# Altium schematic sheet Circuit_SCH.SchDoc
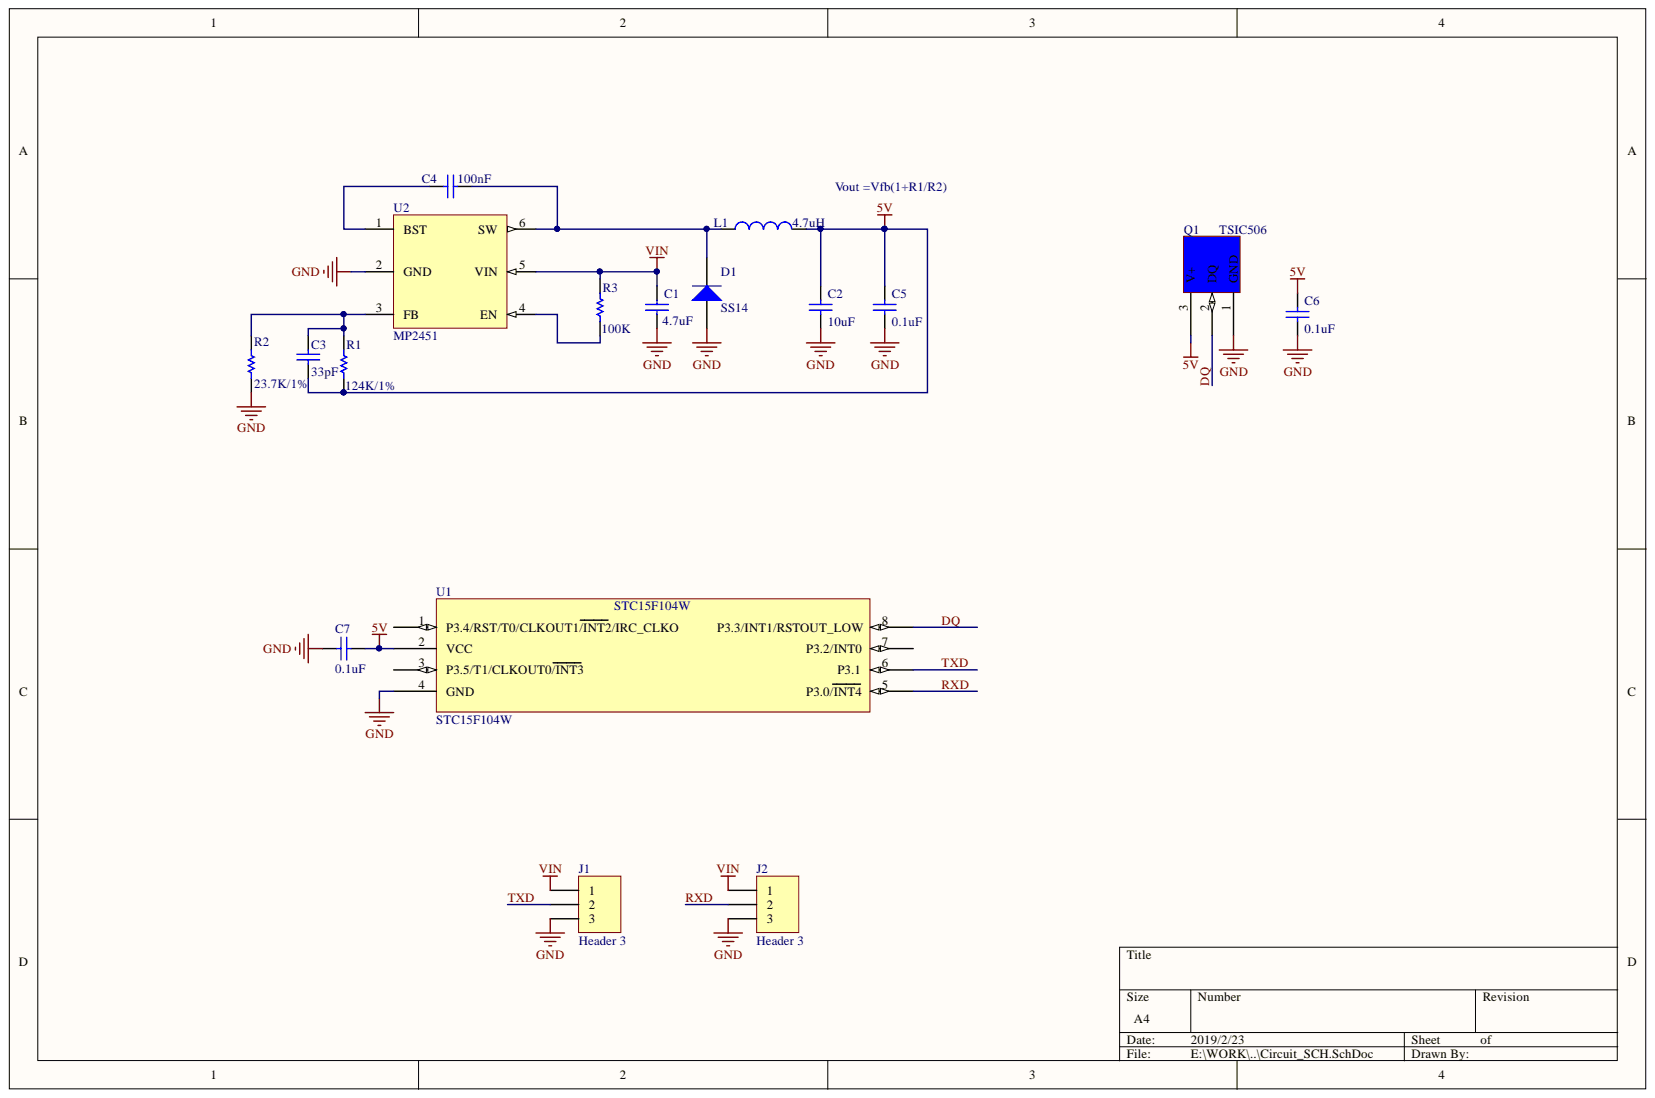
[diagram p0: Circuit_SCH.SchDoc]

## Parts
C1  value 4.7uF  [C0603]  (hidden comment: C0603/Cap)
C2  value 10uF  [C0805]  (hidden comment: C0805/Cap)
C3  value 33pF  [C0603]  (hidden comment: C0603/Cap)
C4  value 100nF  [C0603]  (hidden comment: C0603/Cap)
C5  value 0.1uF  [C0603]  (hidden comment: C0603/Cap)
C6  value 0.1uF  [C0603]  (hidden comment: C0603/Cap)
C7  value 0.1uF  [C0603]  (hidden comment: C0603/Cap)
D1  SS14  [SOD323]
J1  Header 3  [HDR1X3]
J2  Header 3  [HDR1X3]
L1  value 4.7uH  [ind2sm-4x4x3]  (hidden comment: Inductor)
Q1  TSIC506  [TO-92A]
R1  value 124K/1%  [R0603]  (hidden comment: R0603/Res1/1%)
R2  value 23.7K/1%  [R0603]  (hidden comment: R0603/Res1/1%)
R3  value 100K  [R0603]  (hidden comment: R0603/Res1)
U1  STC15F104W  [SO8-150]
U2  MP2451  [SOT23-6]

## Nets
5V: C2.2 C3.1 C5.2 C6.1 C7.1 L1.2 Q1.3 R1.1 U1.2(VCC)
GND: C1.1 C2.1 C5.1 C6.2 C7.2 D1.1 J1.3 J2.3 Q1.1 R2.1 U1.4(GND) U2.2(GND)
VIN: C1.2 J1.1 J2.1 R3.2 U2.5(VIN)
DQ: Q1.2 U1.8(P3.3/INT1/RSTOUT_LOW)
RXD: J2.2 U1.5(P3.0/INT4)
TXD: J1.2 U1.6(P3.1)
NetC3_2: C3.2 R1.2 R2.2 U2.3(FB)
NetC4_1: C4.1 D1.2 L1.1 U2.6(SW)
NetC4_2: C4.2 U2.1(BST)
NetR3_1: R3.1 U2.4(EN)

Not connected: U1 1(P3.4/RST/T0/CLKOUT1/INT2/IRC_CLKO) 3(P3.5/T1/CLKOUT0/INT3) 7(P3.2/INT0)

## Library data of the parts
C1-C7 = Cap: Capacitor; Component Kind: Standard; LatestRevisionDate: 17-Jul-2002; LatestRevisionNote: Re-released for DXP Platform.; Library Name: Miscellaneous Devices.IntLib; PackageDescription: Radial Cap, Thru-Hole; 2 Leads; 0.3 in Pin Spacing; PackageReference: RAD-0.3; Published: 8-Jun-2000; Signal Integrity: Cap; Simulation: CAP; Publisher: Altium Limited
D1 = Diode 1N4007: 1 Amp General Purpose Rectifier; Code_JEDEC: DO-204-AL; Component Kind: Standard; ComponentLink1Description: Manufacturer Link; ComponentLink1URL: http://www.fairchildsemi.com/; ComponentLink2Description: Datasheet; ComponentLink2URL: http://www.fairchildsemi.com/ds/1N%2F1N4001.pdf; DatasheetVersion: 1998; LatestRevisionDate: 17-Jul-2002; LatestRevisionNote: Re-released for DXP Platform.; Library Name: Miscellaneous Devices.IntLib; PackageDescription: Diode, Axial; Body 5.2 x 2.7 mm (LxDia. max), Lead Dia. 0.86 mm (max); PackageReference: DO-41; PackageVersion: Aug-1999; Published: 8-Jun-2000; Signal Integrity: 1N4007; Simulation: 1N4007; Publisher: Altium Limited
J1 J2 = Header 3: Header, 3-Pin; Component Kind: Standard; LatestRevisionDate: 17-Jul-2002; LatestRevisionNote: Re-released for DXP Platform.; Library Name: Miscellaneous Connectors.IntLib; Signal Integrity: Connector; Publisher: Altium Limited
L1 = Inductor: Code_IEC: 1005; Code_JEITA: 0402; Component Kind: Standard; Description: Inductor; LatestRevisionDate: 29-May-2009; LatestRevisionNote: IPC-7351 Footprint Added.; Library Name: Miscellaneous Devices.IntLib; PackageDescription: Chip Inductor; PackageReference: 0402-A; Published: 8-Jun-2000; Signal Integrity: Inductor; Simulation: INDUCTOR; Publisher: Altium Limited
Q1 = TSIC506: Temperature Sensor; Component Kind: Standard; Library Name: Circuit_SchLib.SchLib
R1-R3 = Res1: Resistor; Component Kind: Standard; LatestRevisionDate: 17-Jul-2002; LatestRevisionNote: Re-released for DXP Platform.; Library Name: Miscellaneous Devices.IntLib; PackageDescription: Axial Device, Thru-Hole; 2 Leads; 0.3 in Pin Spacing; PackageReference: AXIAL-0.3; Published: 8-Jun-2000; Signal Integrity: Res; Simulation: RESISTOR; Publisher: Altium Limited
U2 = MP2451: DC-DC; Component Kind: Standard; Library Name: Circuit_SchLib.SchLib
U1 = STC15F104W: Component Kind: Standard; Library Name: Circuit_SchLib.SchLib
